# Altium schematic sheet BTT_M157_Power_V0.3.SchDoc(BTT_M157_Power_V0.3)
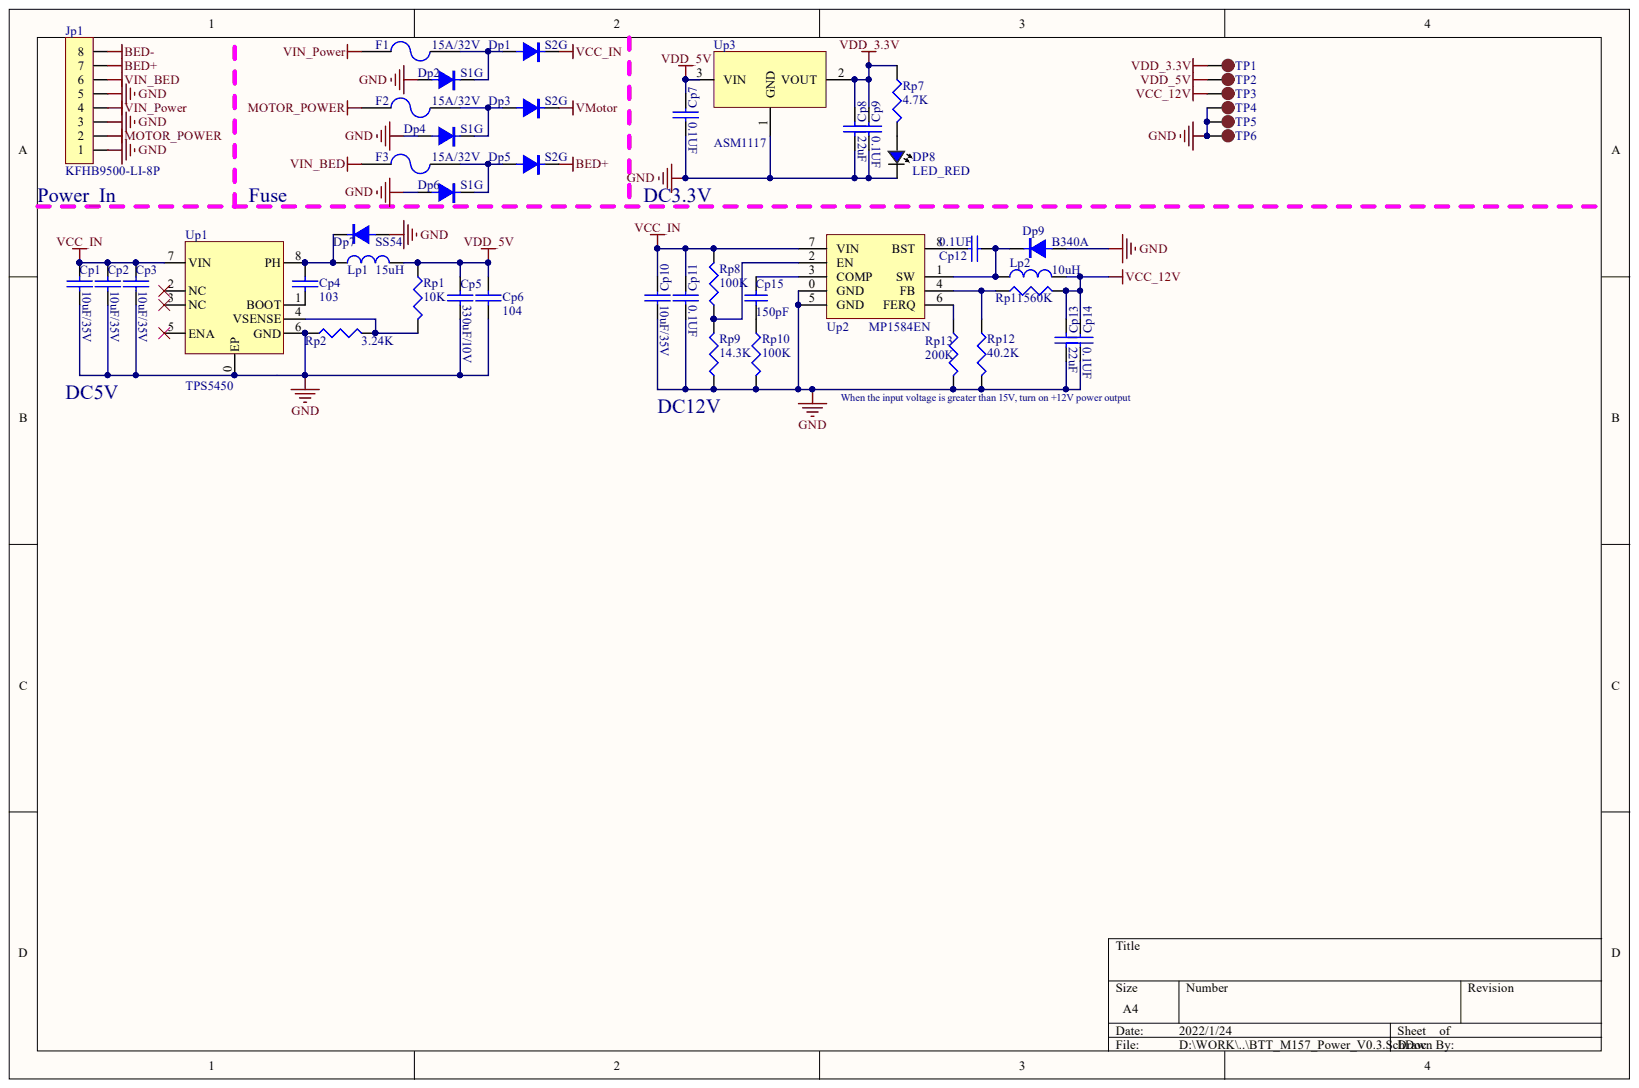
[diagram p0: BTT_M157_Power_V0.3.SchDoc]

## Parts
Cp1  10uF/35V  [1206C]
Cp2  10uF/35V  [1206C]
Cp3  10uF/35V  [1206C]
Cp4  103  [0603C]
Cp5  330uF/10V  [CAP_SMD_6.3*8mm]
Cp6  104  [0603C]
Cp7  0.1UF  [0603C]
Cp8  22uF  [0805C]
Cp9  0.1UF  [0603C]
Cp10  10uF/35V  [1206C]
Cp11  0.1UF  [0603C]
Cp12  0.1UF  [0603C]
Cp13  22uF  [0805C]
Cp14  0.1UF  [0603C]
Cp15  150pF  [0603C]
Dp1  S2G  [DO214AC(SMA)]
Dp2  S1G  [DO214AC(SMA)]
Dp3  S2G  [DO214AC(SMA)]
Dp4  S1G  [DO214AC(SMA)]
Dp5  S2G  [DO214AC(SMA)]
Dp6  S1G  [DO214AC(SMA)]
Dp7  SS54  [DO214AC(SMA)]
DP8  LED_RED  [LED-0603-RED]
Dp9  B340A  [DO214AC(SMA)]
F1  15A/32V  [MCCQ-122 4P]
F2  15A/32V  [MCCQ-122 4P]
F3  15A/32V  [MCCQ-122 4P]
Jp1  KFHB9500-LI-8P  [KFHB9500-LI-8P]
Lp1  15uH  [L0630]
Lp2  10uH  [L0630]
Rp1  10K  [0603R]
Rp2  3.24K  [0603R]
Rp7  4.7K  [0603R]
Rp8  100K  [0603R]
Rp9  14.3K  [0603R]
Rp10  100K  [0603R]
Rp11  560K  [0603R]
Rp12  40.2K  [0603R]
Rp13  200K  [0603R]
TP1  TEST-POINT  [TEST_1.5MM]  (value not drawn)
TP2  TEST-POINT  [TEST_1.5MM]  (value not drawn)
TP3  TEST-POINT  [TEST_1.5MM]  (value not drawn)
TP4  TEST-POINT  [TEST_1.5MM]  (value not drawn)
TP5  TEST-POINT  [TEST_1.5MM]  (value not drawn)
TP6  TEST-POINT  [TEST_1.5MM]  (value not drawn)
Up1  TPS5450  [ESOP8]
Up2  MP1584EN  [ESOP8]
Up3  ASM1117  [SOT-89]

## Nets
BED+: Dp5.2 Jp1.7
BED-: Jp1.8
GND: Cp1.2 Cp2.2 Cp3.2 Cp5.2 Cp6.2 Cp7.2 Cp8.2 Cp9.2 Cp10.2 Cp11.2 Cp13.2 Cp14.2 Dp2.1 Dp4.1 Dp6.1 Dp7.1 DP8.K Dp9.1 Jp1.1 Jp1.3 Jp1.5 Rp2.1 Rp9.1 Rp10.2 Rp12.2 Rp13.2 TP4.1 TP5.1 TP6.1 Up1.0(EP) Up1.6(GND) Up2.0(GND) Up2.5(GND) Up3.1
MOTOR_POWER: F2.2 Jp1.2
VCC_12V: Cp13.1 Cp14.1 Lp2.2 Rp11.2 TP3.1
VCC_IN: Cp1.1 Cp2.1 Cp3.1 Cp10.1 Cp11.1 Dp1.2 Rp8.2 Up1.7(VIN) Up2.7(VIN)
VDD_3.3V: Cp8.1 Cp9.1 Rp7.1 TP1.1 Up3.2
VDD_5V: Cp5.1 Cp6.1 Cp7.1 Lp1.2 Rp1.1 TP2.1 Up3.3
VIN_BED: F3.2 Jp1.6
VIN_POWER: F1.2 Jp1.4
VMOTOR: Dp3.2
NetCp4_1: Cp4.1 Dp7.2 Lp1.1 Up1.8(PH)
NetCp4_2: Cp4.2 Up1.1(BOOT)
NetCp12_1: Cp12.1 Dp9.2 Lp2.1 Up2.1(SW)
NetCp12_2: Cp12.2 Up2.8(BST)
NetCp15_1: Cp15.1 Rp10.1
NetCp15_2: Cp15.2 Up2.3(COMP)
NetDp1_1: Dp1.1 Dp2.2 F1.1
NetDp3_1: Dp3.1 Dp4.2 F2.1
NetDp5_1: Dp5.1 Dp6.2 F3.1
NetDP8_A: DP8.A Rp7.2
NetRp1_2: Rp1.2 Rp2.2 Up1.4(VSENSE)
NetRp8_1: Rp8.1 Rp9.2 Up2.2(EN)
NetRp11_1: Rp11.1 Rp12.1 Up2.4(FB)
NetRp13_1: Rp13.1 Up2.6(FERQ)

Not connected: Up1 2(NC) 3(NC) 5(ENA)

## Library data of the parts
Cp1-Cp6 = CAP: Capacitor; Component Kind: Standard; Library Name: My Useful Discrete Devices.SchLib
Cp7 Cp9-Cp12 Cp14 = CAP: Component Kind: Standard; Library Name: 常用库.SCHLIB
Cp8 Cp13 Cp15 = Cap: Capacitor; Component Kind: Standard; LatestRevisionDate: 17-Jul-2002; LatestRevisionNote: Re-released for DXP Platform.; PackageDescription: Radial Cap, Thru-Hole; 2 Leads; 0.3 in Pin Spacing; PackageReference: RAD-0.3; Published: 8-Jun-2000; Signal Integrity: Cap; Simulation: CAP; Library Name: 常用元器件.SchLib; Publisher: Altium Limited
  Cp8 Cp13: Value: 22uF
  Cp15: Value: 150pF
Dp1-Dp7 Dp9 = DIODE: Component Kind: Standard
  Dp1-Dp6: Library Name: liroqi.SchLib
  Dp7 Dp9: Library Name: 常用库.SCHLIB
DP8 = LED_1: Component Kind: Standard; Library Name: My Useful Discrete Devices.SchLib
F1-F3 = FUSE2: Fuse; Component Kind: Standard; Library Name: My Useful Discrete Devices.SchLib
Jp1 = CON8: Connector; Component Kind: Standard; Library Name: My Useful Discrete Devices.SchLib
Lp1 Lp2 = INDUCTOR: Component Kind: Standard; Library Name: 常用库.SCHLIB
Rp1 Rp2 Rp7-Rp13 = RES1: Component Kind: Standard
  Rp1 Rp2 Rp8-Rp13: Library Name: 常用库.SCHLIB
  Rp7: Library Name: My Useful Discrete Devices.SchLib
TP1-TP6 = TEST-POINT: 测试点; Component Kind: Standard; Library Name: My Useful Discrete Devices.SchLib
Up1 = TPS5450: Buck   Vinmax=36V   Voutmax=36V Ioutmax=5A; Component Kind: Standard; Library Name: My Useful IC.SchLib
Up3 = ASM1117: 三端集成稳压块; Component Kind: Standard; Library Name: IC.SchLib
Up2 = MP1584EN: Component Kind: Standard; Library Name: IC.SchLib
